# Altium schematic sheet Sheet7.SchDoc
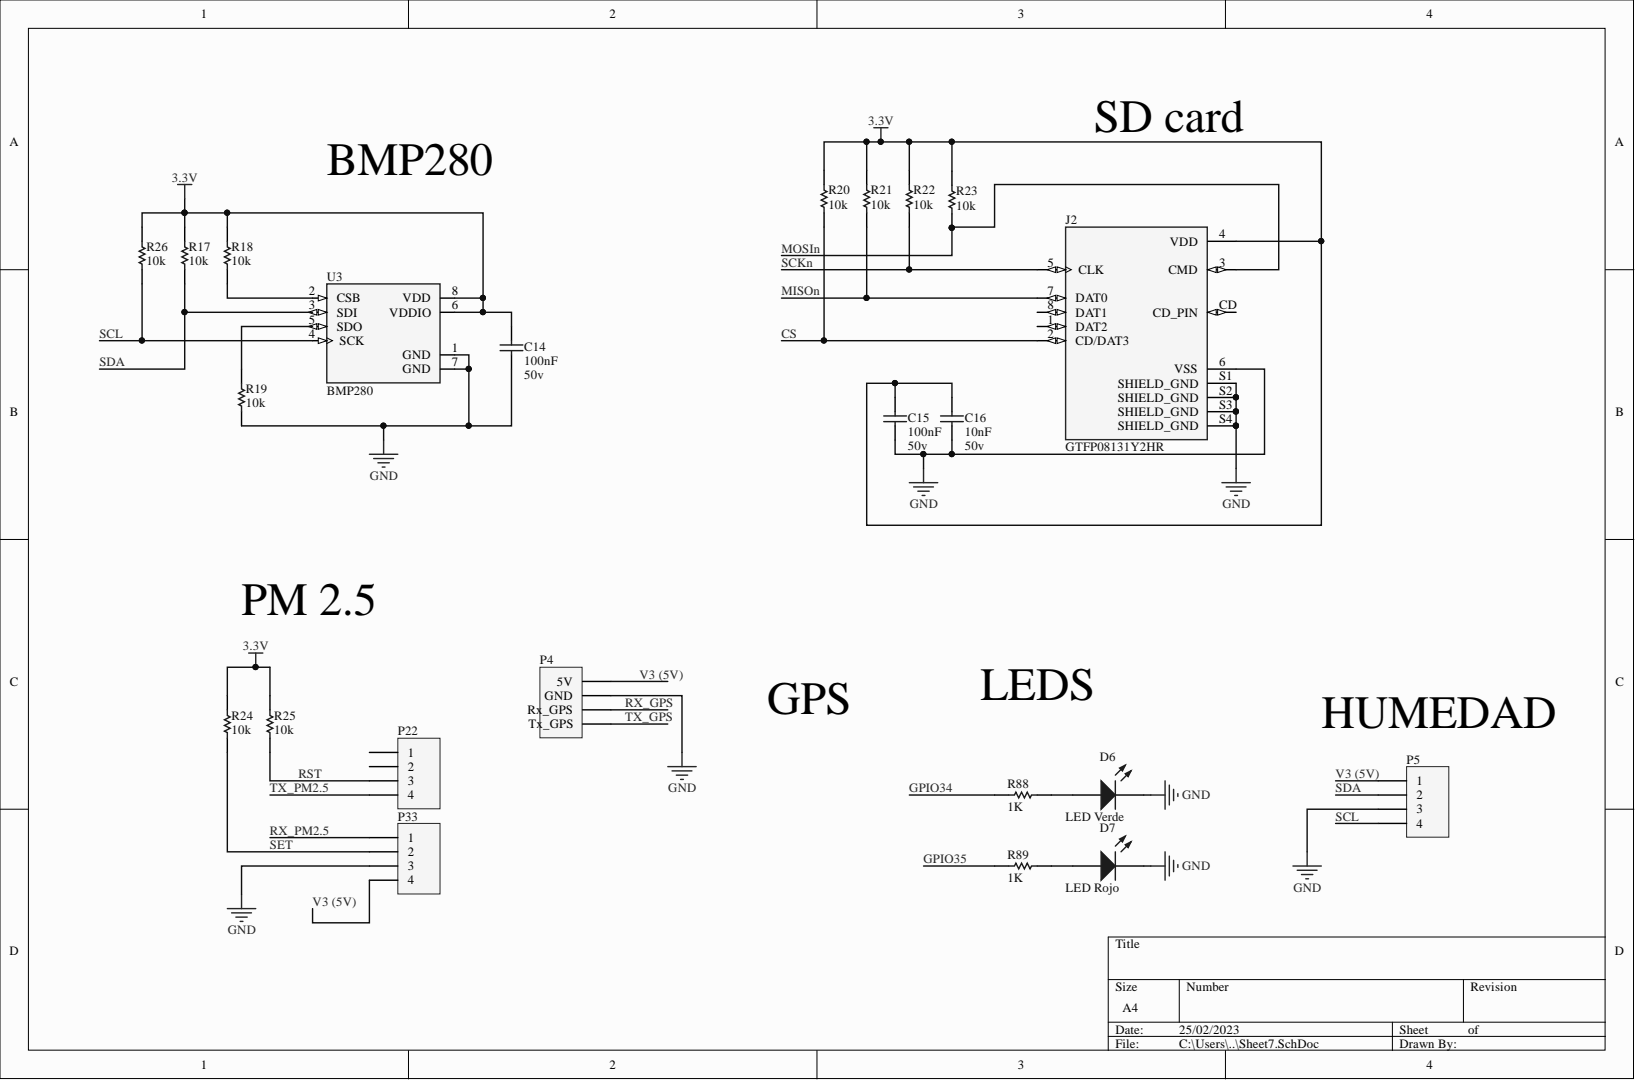
[diagram p0: Sheet7.SchDoc]

## Parts
C14  100nF  Volte: 50v  [MY_0603]
C15  100nF  Volte: 50v  [MY_0603]
C16  10nF  Volte: 50v  [MY_0603]
D6  LED  [MY_LED_0805]
D7  LED  [MY_LED_0805]
J2  GTFP08131Y2HR  [AMPHENOL_GTFP08131Y2HR]
P4  Header 4  [MY_6410-04]  (value not drawn)
P5  Header 4  [MY_6410-04]  (value not drawn)
P22  Header 4  [MY_6410-04]  (value not drawn)
P33  Header 4  [MY_6410-04]  (value not drawn)
R17  10k  [MY_0603]
R18  10k  [MY_0603]
R19  10k  [MY_0603]
R20  10k  [MY_0603]
R21  10k  [MY_0603]
R22  10k  [MY_0603]
R23  10k  [MY_0603]
R24  10k  [MY_0603]
R25  10k  [MY_0603]
R26  10k  [MY_0603]
R88  1K  [MY_0603]
R89  1K  [MY_0603]
U3  BMP280  [XDCR_BMP280]

## Nets
3.3V: C14.2 C15.2 C16.2 J2.4(VDD) R17.2 R18.2 R20.2 R21.2 R22.2 R23.2 R24.2 R25.2 R26.2 U3.6(VDDIO) U3.8(VDD)
GND: C14.1 C15.1 C16.1 D6.K D7.K J2.6(VSS) J2.S1(SHIELD_GND) J2.S2(SHIELD_GND) J2.S3(SHIELD_GND) J2.S4(SHIELD_GND) P4.3(GND) P5.3 P33.3 R19.1 U3.1(GND) U3.7(GND)
CS: J2.2(CD/DAT3) R20.1
GPIO34: R88.2
GPIO35: R89.2
MISOn: J2.7(DAT0) R21.1
MOSIn: J2.3(CMD) R23.1
RST: P22.3 R25.1
RX_GPS: P4.2
RX_PM2.5: P33.1
SCKn: J2.5(CLK) R22.1
SCL: P5.4 R26.1 U3.4(SCK)
SDA: P5.2 R17.1 U3.3(SDI)
SET: P33.2 R24.1
TX_GPS: P4.1
TX_PM2.5: P22.4
V3 (5V): P4.4(5V) P5.1 P33.4
NetD6_A: D6.A R88.1
NetD7_A: D7.A R89.1
NetR18_1: R18.1 U3.2(CSB)
NetR19_2: R19.2 U3.5(SDO)

Not connected: J2 1(DAT2) 8(DAT1) CD(CD_PIN); P22 1 2

## Library data of the parts
C14-C16 = Cap ceramic: None polar capacitor; ASiD Part Number: C-AS; Component Kind: Standard; Library Name: Mylib+Rev+4.IntLib
  C14 C15: Value: 100nF
  C16: Value: 10nF
D6 D7 = LED: Typical INFRARED GaAs LED; ASiD Part Number: LED-AS; Component Kind: Standard; Library Name: Mylib+Rev+4.IntLib
P4 P5 P22 P33 = Header 4: Header, 4-Pin; ASiD Part Number: Header 4-AS; Component Kind: Standard; Library Name: Mylib+Rev+4.IntLib; Signal Integrity: Connector
R17-R26 R88 R89 = Res: Standard resistor; ASiD Part Number: Res-AS; Component Kind: Standard; Library Name: Mylib+Rev+4.IntLib
  R17-R26: Value: 10k
  R88 R89: Value: 1K
U3 = BMP280: BMP280 Absolute Barometric Pressure Sensor for Battery Powered Devices 2 x 2.5mm; Availability: Unavailable; Component Kind: Standard; Library Name: BMP280.IntLib; MP: BMP280; Package: None; Price: None
J2 = GTFP08131Y2HR: Availability: Unavailable; Component Kind: Standard; Library Name: GTFP08131Y2HR.IntLib; MP: GTFP08131Y2HR; Package: None; Price: None
